# Altium schematic sheet H2ST-Dev V1.0.SchDoc
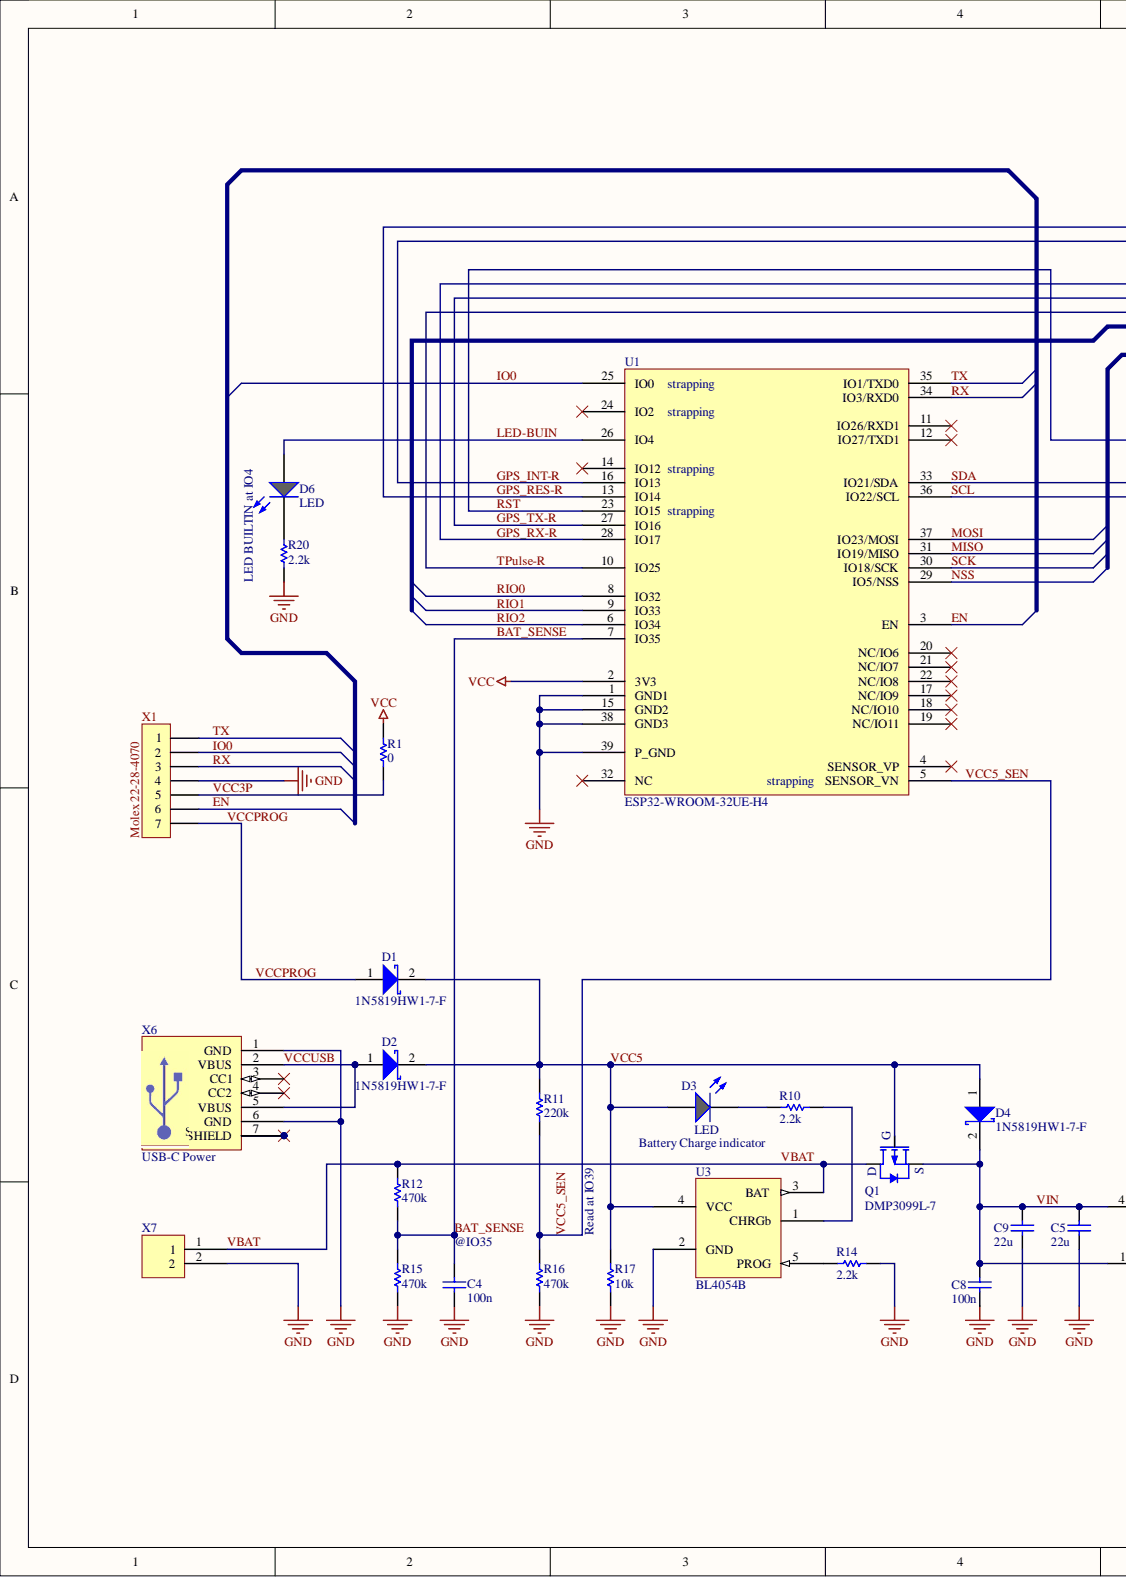
[diagram p0: H2ST-Dev V1.0.SchDoc, left]
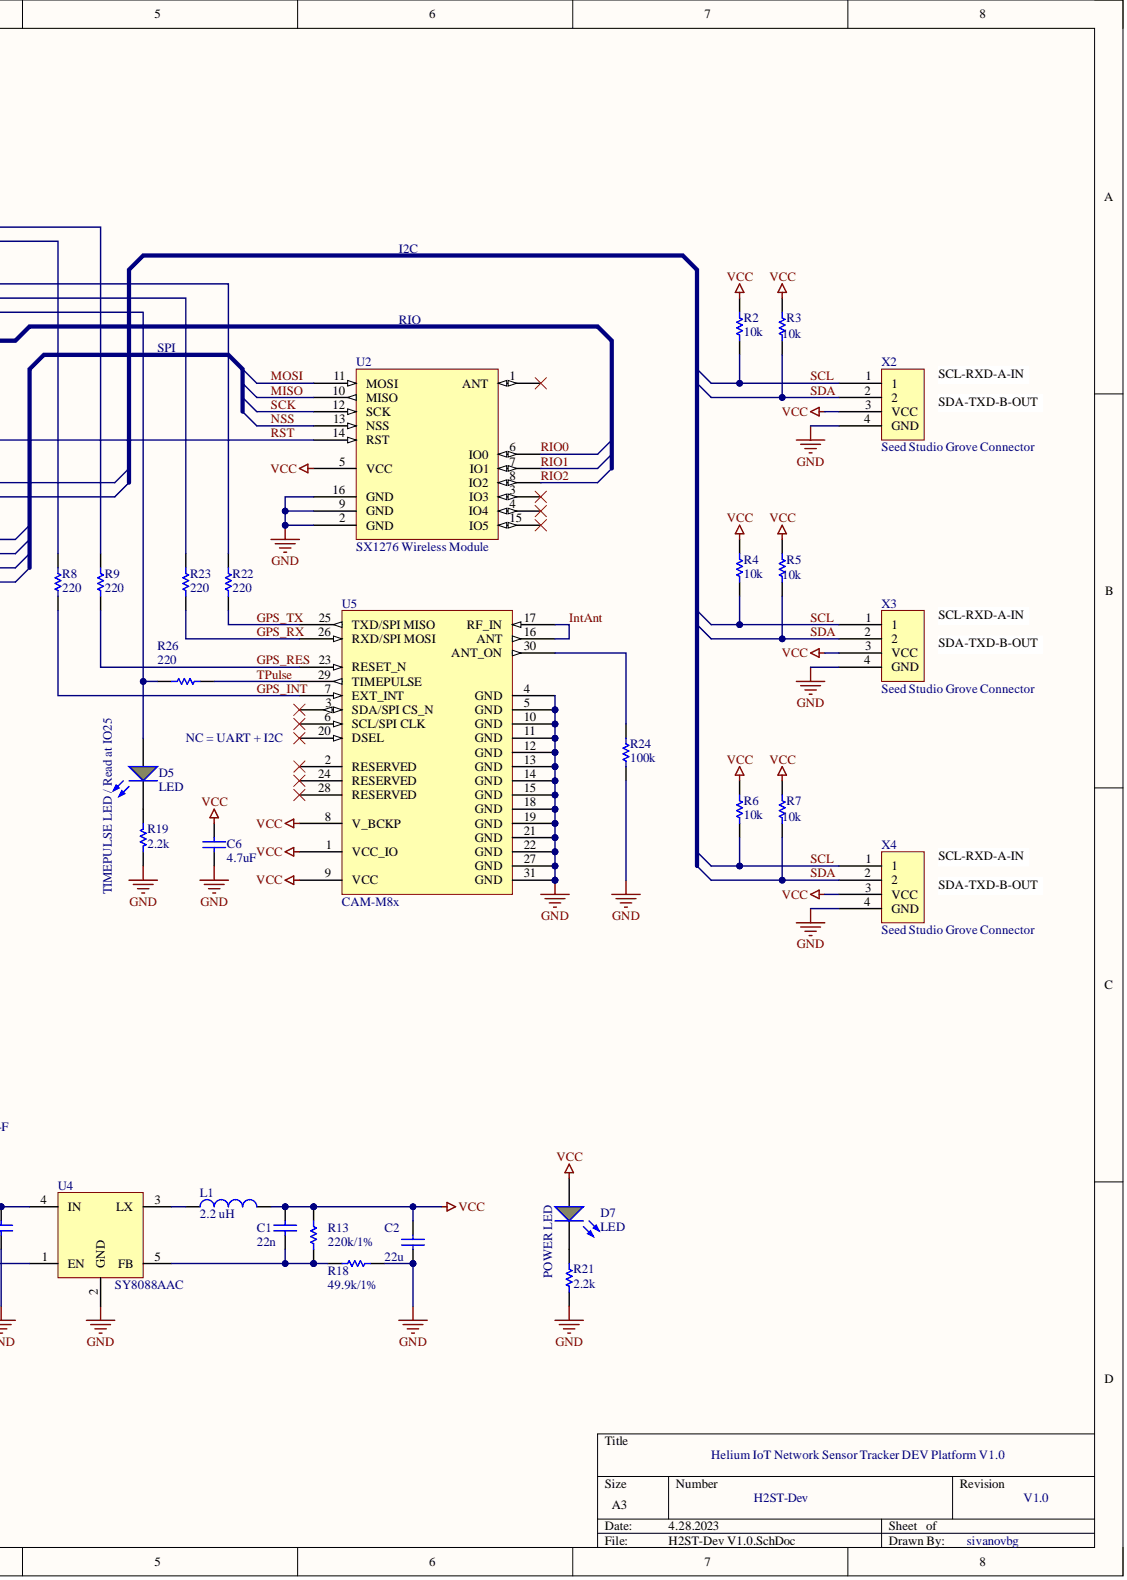
[diagram p1: H2ST-Dev V1.0.SchDoc, right]

## Parts
C1  22n  [CAPC1608X87X35LL15T15]
C2  22u  [CAPC1608X87X35LL15T15]
C4  100n  [CAPC1608X87X35LL15T15]
C5  22u  [CAPC1608X87X35LL15T15]
C6  4.7uF  [CAPC3216X180X80NL20T30]
C8  100n  [CAPC1608X87X35LL15T15]
C9  22u  [CAPC1608X87X35LL15T15]
D1  1N5819HW1-7-F  [SOD123F]
D2  1N5819HW1-7-F  [SOD123F]
D3  LED  [PCB-9uwk2xd6chnjs8ehct7l-1]
D4  1N5819HW1-7-F  [SOD123F]
D5  LED  [PCB-9uwk2xd6chnjs8ehct7l-1]
D6  LED  [PCB-9uwk2xd6chnjs8ehct7l-1]
D7  LED  [PCB-9uwk2xd6chnjs8ehct7l-1]
L1  2.2 uH  [PCB-uy6etyboqxmjvtrywwua-1]
Q1  DMP3099L-7  [NXP-SOT23_L]
R1  0  [PCB-zl41o3f1qfmzro1jm1ta-1]
R2  10k  [RESC1608X55X30LL15T15]
R3  10k  [RESC1608X55X30LL15T15]
R4  10k  [RESC1608X55X30LL15T15]
R5  10k  [RESC1608X55X30LL15T15]
R6  10k  [RESC1608X55X30LL15T15]
R7  10k  [RESC1608X55X30LL15T15]
R8  220  [RESC1608X55X30LL15T15]
R9  220  [RESC1608X55X30LL15T15]
R10  2.2k  [RESC1608X55X30LL15T15]
R11  220k  [RESC1608X55X30LL15T15]
R12  470k  [RESC1608X55X30LL15T15]
R13  220k/1%  [RESC1608X55X30LL15T15]
R14  2.2k  [RESC1608X55X30LL15T15]
R15  470k  [RESC1608X55X30LL15T15]
R16  470k  [RESC1608X55X30LL15T15]
R17  10k  [RESC1608X55X30LL15T15]
R18  49.9k/1%  [RESC1608X55X30LL15T15]
R19  2.2k  [RESC1608X55X30LL15T15]
R20  2.2k  [RESC1608X55X30LL15T15]
R21  2.2k  [RESC1608X55X30LL15T15]
R22  220  [RESC1608X55X30LL15T15]
R23  220  [RESC1608X55X30LL15T15]
R24  100k  [RESC1608X55X30LL15T15]
R26  220  [RESC1608X55X30LL15T15]
U1  ESP32-WROOM-32UE-H4  [PCB-vi9mwvbfu59804fvghnf-1]
U2  SX1276 Wireless Module  [SX1276 Wireless Module]
U3  BL4054B  [TI-DBV5_L]
U4  SY8088AAC  [PCB-mohzzsago8wk4ztl8rha-1]
U5  CAM-M8x  [PCB-gnuhmudpeq0medn91hzx-1]
X1  Molex 22-28-4070  Manufacturer Part Number: 22-28-4070  [PCB-r6936oc4qtzihhpi5008-1]
X2  Seed Studio Grove Connector  [PCB-eeaqzy56yc1pr5mp2t8j-1]
X3  Seed Studio Grove Connector  [PCB-eeaqzy56yc1pr5mp2t8j-1]
X4  Seed Studio Grove Connector  [PCB-eeaqzy56yc1pr5mp2t8j-1]
X6  USB-C Power  [Molex_217175-0001-Footprint-1]
X7  JST B2B-PH-K-S(LF)(SN)  [PCB-gpdi66yn1jko56crxrq7-1]  (value not drawn)

## Nets
GND: C2.1 C4.1 C5.1 C6.1 C8.1 C9.1 R14.1 R15.1 R16.1 R17.1 R18.1 R19.1 R20.1 R21.1 R24.1 U1.1(GND1) U1.15(GND2) U1.38(GND3) U1.39(P_GND) U2.2(GND) U2.9(GND) U2.16(GND) U3.2(GND) U4.2(GND) U5.4(GND) U5.5(GND) U5.10(GND) U5.11(GND) U5.12(GND) U5.13(GND) U5.14(GND) U5.15(GND) U5.18(GND) U5.19(GND) U5.21(GND) U5.22(GND) U5.27(GND) U5.31(GND) X1.4 X2.4(GND) X3.4(GND) X4.4(GND) X6.1(GND) X6.6(GND) X7.2
VCC: C1.2 C2.2 C6.2 D7.A L1.2 R1.1 R2.2 R3.2 R4.2 R5.2 R6.2 R7.2 R13.1 U1.2(3V3) U2.5(VCC) U5.1(VCC_IO) U5.8(V_BCKP) U5.9(VCC) X2.3(VCC) X3.3(VCC) X4.3(VCC)
BAT_SENSE: C4.2 R12.1 R15.2 U1.7(IO35)
EN: U1.3(EN) X1.6
GPS_INT: R8.2 U5.7(EXT_INT)
GPS_INT-R: R8.1 U1.16(IO13)
GPS_RES: R9.2 U5.23(RESET_N)
GPS_RES-R: R9.1 U1.13(IO14)
GPS_RX: R23.2 U5.26(RXD/SPI_MOSI)
GPS_RX-R: R22.1 U1.28(IO17)
GPS_TX: R22.2 U5.25(TXD/SPI_MISO)
GPS_TX-R: R23.1 U1.27(IO16)
IntAnt: U5.16(ANT) U5.17(RF_IN)
IO0: U1.25(IO0) X1.2
LED-BUIN: D6.A U1.26(IO4)
MISO: U1.31(IO19/MISO) U2.10(MISO)
MOSI: U1.37(IO23/MOSI) U2.11(MOSI)
NSS: U1.29(IO5/NSS) U2.13(NSS)
RIO0: U1.8(IO32) U2.6(IO0)
RIO1: U1.9(IO33) U2.7(IO1)
RIO2: U1.6(IO34) U2.8(IO2)
RST: U1.23(IO15) U2.14(RST)
RX: U1.34(IO3/RXD0) X1.3
SCK: U1.30(IO18/SCK) U2.12(SCK)
SCL: R2.1 R4.1 R6.1 U1.36(IO22/SCL) X2.1 X3.1 X4.1
SDA: R3.1 R5.1 R7.1 U1.33(IO21/SDA) X2.2 X3.2 X4.2
TPulse: R26.2 U5.29(TIMEPULSE)
TPulse-R: D5.A R26.1 U1.10(IO25)
TX: U1.35(IO1/TXD0) X1.1
VBAT: Q1.3 R12.2 U3.3(BAT) X7.1
VCC3P: R1.2 X1.5
VCC5: D1.2 D2.2 D3.A D4.1 Q1.1 R11.2 R17.2 U3.4(VCC)
VCC5_SEN: R11.1 R16.2 U1.5(SENSOR_VN)
VCCPROG: D1.1 X1.7
VCCUSB: D2.1 X6.2(VBUS) X6.5(VBUS)
VIN: C5.2 C8.2 C9.2 D4.2 Q1.2 U4.1(EN) U4.4(IN)
NetC1_1: C1.1 R13.2 R18.2 U4.5(FB)
NetD3_C: D3.C R10.2
NetD5_C: D5.C R19.2
NetD6_C: D6.C R20.2
NetD7_C: D7.C R21.2
NetL1_1: L1.1 U4.3(LX)
NetR10_1: R10.1 U3.1(CHRGb)
NetR14_2: R14.2 U3.5(PROG)
NetR24_2: R24.2 U5.30(ANT_ON)
NetX6_7: X6.7(SHIELD) X6.8 X6.9 X6.10

Not connected: U1 4(SENSOR_VP) 11(IO26/RXD1) 12(IO27/TXD1) 14(IO12) 17(NC/IO9) 18(NC/IO10) 19(NC/IO11) 20(NC/IO6) 21(NC/IO7) 22(NC/IO8) 24(IO2) 32(NC); U2 1(ANT) 3(IO3) 4(IO4) 15(IO5); U5 2(RESERVED) 3(SDA/SPI_CS_N) 6(SCL/SPI_CLK) 20(DSEL) 24(RESERVED) 28(RESERVED); X6 3(CC1) 4(CC2)

## Library data of the parts
C1 C4 = CMP-130060-3: CAP CER 2200PF 50V 10% X8R 0603; Manufacturer Part Number: C0603C222K5HACTU; Capacitance: 2.2e-09 F; Dielectric Material: Ceramic; Lead-Free Status: Lead Free; Voltage Rating (DC): 50.0 V; Capacitance Tolerance: ±10%; Case/Package: 0603; Component Kind: Standard; Dielectric Characteristic: X8R; Library Name: My Personal Space; Mounting Style: Surface Mount; Octopart Url: https://octopart.com/c0603c222k5hactu-kemet-19034686; OctopartID: f5c03de8a2982a07; RoHS: Compliant
C2 C5 C8 C9 = CMP-130060-3: CAP CER 2200PF 50V 10% X8R 0603; Capacitance: 22uF; Dielectric Characteristic: X5R; Dielectric Material: Ceramic; Lead-Free Status: Lead Free; Voltage Rating (DC): 6.3; Capacitance Tolerance: ±10%; Case/Package: 0603; Component Kind: Standard; Library Name: My Personal Space; Mounting Style: Surface Mount; RoHS: Compliant
C6 = CMP-16524620-2: Manufacturer Part Number: TAJA226M006RNJ; Capacitance: 2.2e-05 F; Capacitance Tolerance: ±20%; Equivalent Series Resistance (ESR): 3.0 Ω; Lead-Free Status: Lead Free; Voltage Rating (DC): 6.3 V; Case/Package: 1206; Component Kind: Standard; Library Name: My Personal Space; Mounting Style: Surface Mount; Number of Pins: 2; Octopart Url: https://octopart.com/taja226m006rnj-avx+interconnect+%2F+elco-3912279; OctopartID: 96185f29012949df; Packaging: Cut Tape (CT); REACH SVHC Compliance: No SVHC; RoHS: Compliant
D1 D2 D4 = CMP-f1953c9df3432e52-4: 1N5819 Schottky Diode 40V 1A SOD123F; Component Kind: Standard; Library Name: My Personal Space
D3 D5-D7 = CMP-ec4c254b98bc338b-4: Led; 0603 Smt Led, Green, Alingap, Water Clear Lens, 140 Deg Viewing Angle; Case/Package (SI): 1608; Lead-Free Status: Lead Free; Component Kind: Standard; Library Name: CommunityVault; Number of Pins: 2; Octopart URL: http://octopart.com/598-8070-107f-dialight-872854; Size-Height: 700 µm; Size-Length: 1.60 mm
L1 = CMP-96125f1d548e49d5-1: Inductor Power Shielded Wirewound 4.7uH 30% 100KHz Ferrite 1.71A 98mOhm DCR 1515 Blister T/R; Manufacturer Part Number: TDK VLCF4020T-2R2N1R7; Inductance: 2.2 µH; Lead-Free Status: Lead Free; Shielding: Yes; Component Kind: Standard; Library Name: My Personal Space; Mounting Style: Surface Mount; Number of Pins: 2; Operating Temperature: 105 °C (max); Packaging: Tape & Reel (TR), Cut Tape (CT); REACH SVHC Compliance: No SVHC; RoHS: Compliant; Size-Height: 2.00 mm; Size-Length: 4.00 mm; Size-Width: 4.00 mm
Q1 = CMP-a6e66e34d61c7921-8: DMP3099L Series 30 V 65 mOhm P-Channel Enhancement Mode Mosfet - SOT-23-3; Component Kind: Standard; Library Name: My Personal Space
R1 = CMP-912d5a4146004eea-3: Res Thick Film 3.2 x 1.6 mm 100 Ohm 5% 0.25W(1/4W) 100ppm/ C Molded SMD Paper T/R; Manufacturer Part Number: RC1206JR-07100RL; Case/Package (SI): 3216; Lead-Free Status: Lead Free; Power Rating: 250 mW; Resistance: 100 Ω; Voltage Rating (DC): 200 V; Case/Package: 1206; Component Kind: Standard; Library Name: My Personal Space; Mounting Style: Surface Mount; Number of Pins: 2; Octopart URL: http://octopart.com/rc1206jr-07100rl-yageo-40301131; OctopartID: 912d5a4146004eea; Packaging: Tape & Reel; REACH SVHC Compliance: No SVHC; Resistance Tolerance: ±5%; RoHS: Compliant; Size-Height: 550 µm; Size-Length: 3.20 mm; Size-Width: 1.60 mm
R2-R24 R26 = CMP-3652450-2: Thick Film Resistors - SMD 0603 107ohms 1% Tol; Lead-Free Status: Contains Lead; Power Rating: 0.1 W; Resistance: 5 k; Voltage Rating (DC): 50.0 V; Case/Package: 0603; Component Kind: Standard; Library Name: CommunityVault; Lifecycle Status: Not Listed by Manufacturer; Mounting Style: Surface Mount; Octopart Url: https://octopart.com/erj-3ekf1070v-panasonic-55421396; OctopartID: e4812ec668333b46; REACH SVHC Compliance: No SVHC; Resistance Tolerance: ±1%; RoHS: Compliant; Size-Height: 0.00045 m; Size-Length: 0.0016 m; Size-Width: 0.0008 m
U1 = CMP-e91d2793568336da-3: Espressif Systems ESP32-WROOM-32UE-H4; Component Kind: Standard; Library Name: My Personal Space; Manufacturer Part Number: ESP32-WROOM-32UE-H4; Octopart Url: https://octopart.com/esp32-wroom-32ue-h4-espressif+systems-126130936; OctopartID: e91d2793568336da
U2 = CMP-t36gs7nny8mj0h396y35-4: Lora 868M/915MHz Module SX1276 Wireless Transceiver Module spread Spectrum Long-Range Wireless Communication LORA/GFSK by YX Electronic Components / AE; Component Kind: Standard; ComponentLink1Description: URL; ComponentLink1URL: https://www.aliexpress.com/item/32962551530.html?spm=a2g0o.order_list.order_list_main.9.52df1802HHbOE6; CustomComponentID: t36gs7nny8mj0h396y35; Library Name: My Personal Space
U3 = CMP-iiskgumscrp1dm5zl7hc-1: 800mA Standalone Linear Li-Ion Battery Charger with Thermal Regulation in SOT23-5/TSOT23-5; Component Kind: Standard; CustomComponentID: iiskgumscrp1dm5zl7hc; Library Name: My Personal Space
U4 = CMP-1c09d1d460ddc3ce-3: Synchronous Step Down Regulator 1.5m 1a; Component Kind: Standard; Library Name: My Personal Space; Manufacturer Part Number: SY8088AAC; Octopart URL: http://octopart.com/sy8088aac-silergy-81222066; OctopartID: 1c09d1d460ddc3ce
U5 = CMP-7474b87837c3abb3-4: Ic Receiver Gps Chip Ant 31lcc; Component Kind: Standard; Library Name: My Personal Space
X1 = CMP-530c9a0248f58ccb-3: KK® 254 Breakaway Header, Vertical, 7 Circuits, Tin (Sn) Plating, Mating Pin Length 6.09mm; Current Rating: 4.00 A; Gender: Male; Glow Wire Compliant: Non-Compliant; Lead-Free Status: Lead Free; Number of Circuits: 7, 6; Number of Contacts: 7; Pin Pitch: 2.54 mm; Voltage Rating (DC): 250 V; Component Kind: Standard; Contact Material: Brass; Contact Plating: Tin; Flammability Rating: UL 94 V-0; Halogen Free Status: Not Low Halogen; Housing Color: Black; Housing Material: Nylon; Library Name: My Personal Space; Lifecycle Status: Active; Mounting Style: Through Hole, Solder; Number of Positions: 7; Number of Rows: 1; Octopart URL: https://octopart.com/22-28-4070-molex-278175; OctopartID: 530c9a0248f58ccb; Operating Temperature: 75.0 °C (max); Orientation: Vertical; Packaging: Bulk; RoHS: Compliant; Size-Height: 2.29 mm; Size-Length: 17.8 mm; Size-Width: 2.49 mm
X2-X4 = CMP-0ae4c01a831dd523-4: [Seeed Studio] 110990030 Grove - Universal 4 pin connector; Component Kind: Standard; Library Name: My Personal Space; Manufacturer Part Number: 110990030; Octopart URL: https://octopart.com/110990030-seeed+studio-66366623; OctopartID: 0ae4c01a831dd523
X6 = CMP-b08d7f7b4b176f4a-19: Connector USB Type C Female 6Positions 0.5mm Right Angle SMT Embossed T/R - Molex; Manufacturers Part Number: 217175-0001; Component Kind: Standard; ComponentLink1Description: MFG URL; ComponentLink1URL: https://www.molex.com/molex/products/part-detail/io_connectors/2171750001#; Library Name: My Personal Space; Manufacturer: Molex; OctopartID: b08d7f7b4b176f4a
X7 = CMP-642947-7: PH Series 2 Position 2 mm Pitch Through Hole Top Entry Shrouded Header; Contact Resistance: 10.0 mΩ; Current Rating: 2.00 A; Gender: Male; Insulation Resistance: 1.00 MΩ; Lead-Free Status: Lead Free; Number of Contacts: 2; Pin Pitch: 2.00 mm; Voltage Rating (AC): 100 V; Component Kind: Standard; Contact Material: Brass; Contact Plating: Tin; Housing Color: Natural; Housing Material: Nylon; Library Name: CommunityVault; Manufacturer Part Number: B2B-PH-K-S(LF)(SN); Mounting Style: Through Hole, Vertical; Number of Positions: 2; Number of Rows: 1; Octopart URL: http://octopart.com/b2b-ph-k-s%28lf%29%28sn%29-jst-248868; OctopartID: a21c6b154b5e7ddb; Packaging: Bulk; REACH SVHC Compliance: No SVHC; RoHS: Compliant; Size-Height: 6.00 mm; Size-Length: 5.90 mm; Size-Width: 4.50 mm
